# Altium schematic sheet pca20018 - Sensors.SchDoc
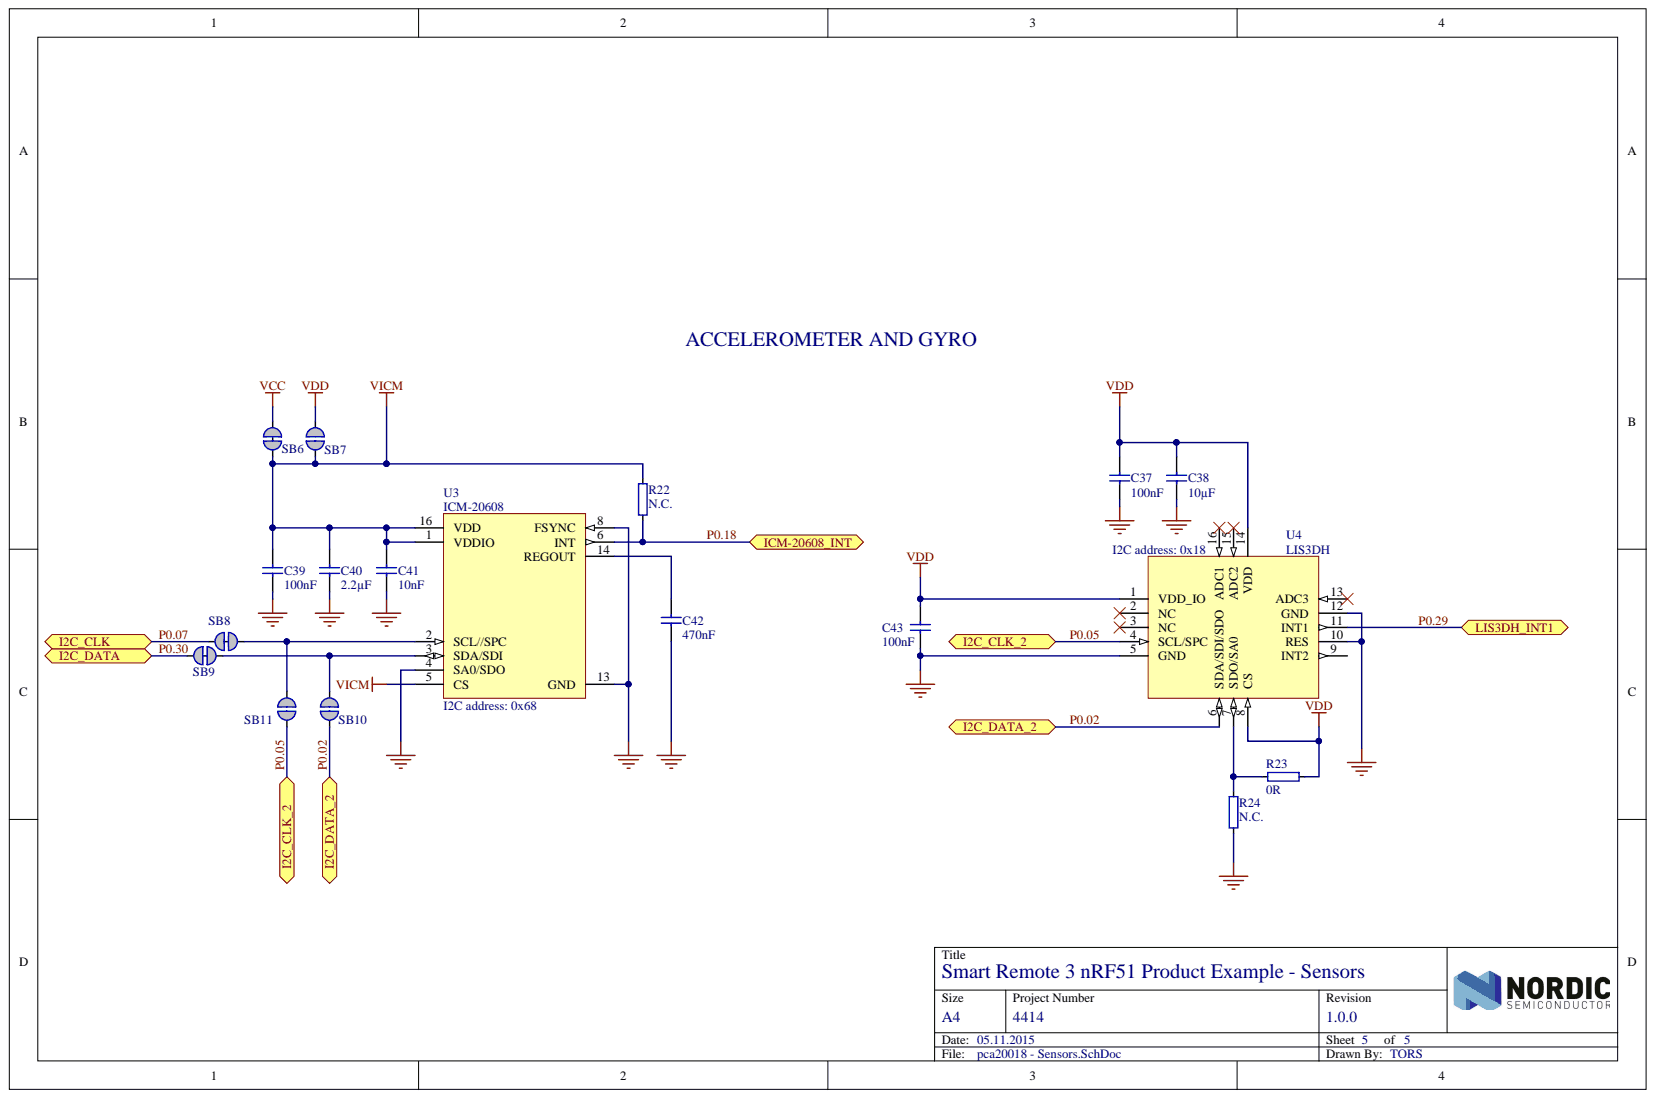
[diagram p0: pca20018 - Sensors.SchDoc]

## Parts
C37  100nF  [CAPC1005X04L]
C38  10µF  [CAPC2012X11L]
C39  100nF  [CAPC1005X04L]
C40  2.2µF  [CAPC1005X04L]
C41  10nF  [CAPC1005X04L]
C42  470nF  [CAPC1005X04L]
C43  100nF  [CAPC1005X04L]
R22  N.C.  [RESC1005X04L]
R23  0R  [RESC1005X04L]
R24  N.C.  [RESC1005X04L]
SB6  Solderbridge  [Solderbridge_Shorted]  (value not drawn)
SB7  Solderbridge  [Solderbridge]  (value not drawn)  (no BOM)
SB8  Solderbridge  [Solderbridge_Shorted]  (value not drawn)
SB9  Solderbridge  [Solderbridge_Shorted]  (value not drawn)
SB10  Solderbridge  [Solderbridge]  (value not drawn)  (no BOM)
SB11  Solderbridge  [Solderbridge]  (value not drawn)  (no BOM)
U3  ICM-20608  [PQFN50P300X300X80-16N]
U4  LIS3DH  [PQFN50P300X300X100-16N]

## Ports
I2C_CLK, I2C_CLK_2, I2C_DATA, I2C_DATA_2, ICM-20608_INT, LIS3DH_INT1

## Nets
GND: C37.1 C38.1 C39.1 C40.1 C41.1 C42.1 C43.1 R24.1 U3.4(SA0/SDO) U3.8(FSYNC) U3.9 U3.10 U3.11 U3.12 U3.13(GND) U3.15 U4.5(GND) U4.10(RES) U4.12(GND)
VCC: SB6.1
VDD: C37.2 C38.2 C43.2 R23.2 SB7.1 U4.1(VDD_IO) U4.8(CS) U4.14(VDD)
VICM: C39.2 C40.2 C41.2 R22.2 SB6.2 SB7.2 U3.1(VDDIO) U3.5(CS) U3.16(VDD)
P0.02: SB10.2 U4.6(SDA/SDI/SDO) ; port I2C_DATA_2
P0.05: SB11.2 U4.4(SCL/SPC) ; port I2C_CLK_2
P0.07: SB8.1 ; port I2C_CLK
P0.18: R22.1 U3.6(INT) ; port ICM-20608_INT
P0.29: U4.11(INT1) ; port LIS3DH_INT1
P0.30: SB9.1 ; port I2C_DATA
NetC42_2: C42.2 U3.14(REGOUT)
NetR23_1: R23.1 R24.2 U4.7(SDO/SA0)
NetSB8_2: SB8.2 SB11.1 U3.2(SCL//SPC)
NetSB9_2: SB9.2 SB10.1 U3.3(SDA/SDI)

Not connected: U4 2(NC) 3(NC) 9(INT2) 13(ADC3) 15(ADC2) 16(ADC1)

## Library data of the parts
C37 C39 C43 = C0402-100n: Capacitor, X7R, ±10%; Artikkelnr Noca: 20039200; Artikkelnr Simpro: N.A.; Database Table Name: Capacitors$; Note: 16V; Component Kind: Standard; Footprint Doc: 0402; LatestRevisionDate: 2009-11-23; Library Name: Nordic Passive DB.DbLib; Part Number: C0402-100n; Pin Count: 2; Published: 2009-05-08; Value: 100nF; Publisher: Nordic Semiconductor
C38 = C0805-10µ: Capacitor, X5R, ±10%; Artikkelnr Noca: 20039243; Artikkelnr Simpro: N.A.; Database Table Name: Capacitors$; Note: 16V; Component Kind: Standard; Footprint Doc: 0805; LatestRevisionDate: 2013-06-04; Library Name: Nordic Passive DB.DbLib; Part Number: C0805-10µ; Pin Count: 2; Published: 2010-06-03; Value: 10µF; Publisher: Nordic Semiconductor
C40 = C0402-2µ2: Chip Cap 0402; Artikkelnr Noca: N.A.; Database Table Name: Capacitors$; Note: 25V; Artikkelnr Simpro: N.A.; Component Kind: Standard; Footprint Doc: 0402; LatestRevisionDate: 2009-05-08; Library Name: Nordic Passive DB.DbLib; Part Number: C0402-2µ2; Pin Count: 2; Published: 2009-05-08; Supplier: Digi-Key; Supplier part number: 490-10457-1-ND; Value: 2.2µF; Publisher: Nordic Semiconductor
C41 = C0402-10n: Capacitor, X7R, ±10%; Artikkelnr Noca: 20039166; Artikkelnr Simpro: N.A.; Database Table Name: Capacitors$; Note: 16V; Component Kind: Standard; Footprint Doc: 0402; LatestRevisionDate: 2009-11-23; Library Name: Nordic Passive DB.DbLib; Part Number: C0402-10n; Pin Count: 2; Published: 2009-05-08; Value: 10nF; Publisher: Nordic Semiconductor
C42 = C0402-470n: Capacitor, X5R, ±10%; Artikkelnr Noca: 20039350; Artikkelnr Simpro: N.A.; Database Table Name: Capacitors$; Note: 6.3V; Component Kind: Standard; Footprint Doc: 0402; LatestRevisionDate: 2015-07-03; Library Name: Nordic Passive DB.DbLib; Part Number: C0402-470n; Pin Count: 2; Published: 2009-05-08; Value: 470nF; Publisher: Nordic Semiconductor
R22 R24 = R0402-N.C.: Not mounted; Artikkelnr Noca: N.A.; Database Table Name: Resistors$; Note: Chip Res; Artikkelnr Simpro: N.A.; Component Kind: Standard; Footprint Doc: 0402; LatestRevisionDate: 2009-05-08; Library Name: Nordic Passive DB.DbLib; Part Number: R0402-N.C.; Pin Count: 2; Published: 2009-05-08; Value: N.C.; Publisher: Nordic Semiconductor
R23 = R0402-0R: Resistor, ±5%, 0.063W; Artikkelnr Noca: 27049000; Artikkelnr Simpro: N.A.; Database Table Name: Resistors$; Note: Chip Res 5%; Component Kind: Standard; Footprint Doc: 0402; LatestRevisionDate: 2009-11-23; Library Name: Nordic Passive DB.DbLib; Part Number: R0402-0R; Pin Count: 2; Published: 2009-05-08; Supplier: Farnell; Supplier part number: 146-9661; Value: 0R; Publisher: Nordic Semiconductor
SB6 SB8 SB9 = Solderbridge Shorted: Shorted Solderbridge; Artikkelnr Noca: N.A.; Database Table Name: Connectors$; Artikkelnr Simpro: N.A.; Component Kind: Net Tie; Footprint Doc: Solderbridge_Shorted; LatestRevisionDate: 2012-08-07; Library Name: Nordic Passive DB.DbLib; Part Number: Solderbridge Shorted; Pin Count: 2; Published: 2012-08-07; Value: Solderbridge; Publisher: Nordic Semiconductor
SB7 SB10 SB11 = Solderbridge: Artikkelnr Noca: N.A.; Database Table Name: Connectors$; Artikkelnr Simpro: N.A.; Description: Solderbridge; Footprint Doc: Solderbridge; LatestRevisionDate: 2009-12-14; Library Name: Nordic Passive DB.DbLib; Part Number: Solderbridge; Pin Count: 2; Published: 2009-12-14; Value: Solderbridge; Publisher: Nordic Semiconductor
U3 = ICM-20608: Gyroscope and Accelerometer, PQFN-16; Manufacturer: InvenSense; Artikkelnr Noca: N.A.; Database Table Name: Misc IC$; Artikkelnr Simpro: N.A.; Component Kind: Standard; Footprint Doc: PQFN-16; LatestRevisionDate: 2015-02-26; Library Name: Nordic Active DB.DbLib; Manufacturer Part Number: ICM-20608; Part Number: ICM-20608; Pin Count: 16; Published: 2015-02-26; Supplier: Digi-Key; Supplier part number: 1428-1052-1-ND; Value: ICM-20608; Publisher: Nordic Semiconductor
U4 = LIS3DH: 3-axis - ±2g/±4g/±8g/±16g digital output nano accelerometer; 2nd source part number: 187-2923; Manufacturer: STMicroelectronics; Artikkelnr Noca: 15079978; Artikkelnr Simpro: N.A.; Database Table Name: Misc IC$; Note: MEMS digital output motion sensor ultra low-power high performance 3-axes "nano" accelerometer; 2nd source Supplier: Farnell; Component Kind: Standard; Datasheet: http://www.st.com/st-web-ui/static/active/en/resource/technical/document/datasheet/CD00274221.pdf; Footprint Doc: LGA-16; LatestRevisionDate: 2015-01-09; Library Name: Nordic Active DB.DbLib; Manufacturer Part Number: LIS3DH; Part Number: LIS3DH; Pin Count: 16; Published: 2011-08-30; Supplier: Digi-Key; Supplier part number: 497-10613-1-ND; Value: LIS3DH; Publisher: Nordic Semiconductor
